# Altium schematic sheet 9.PCA9685.SchDoc(9.PCA9685)
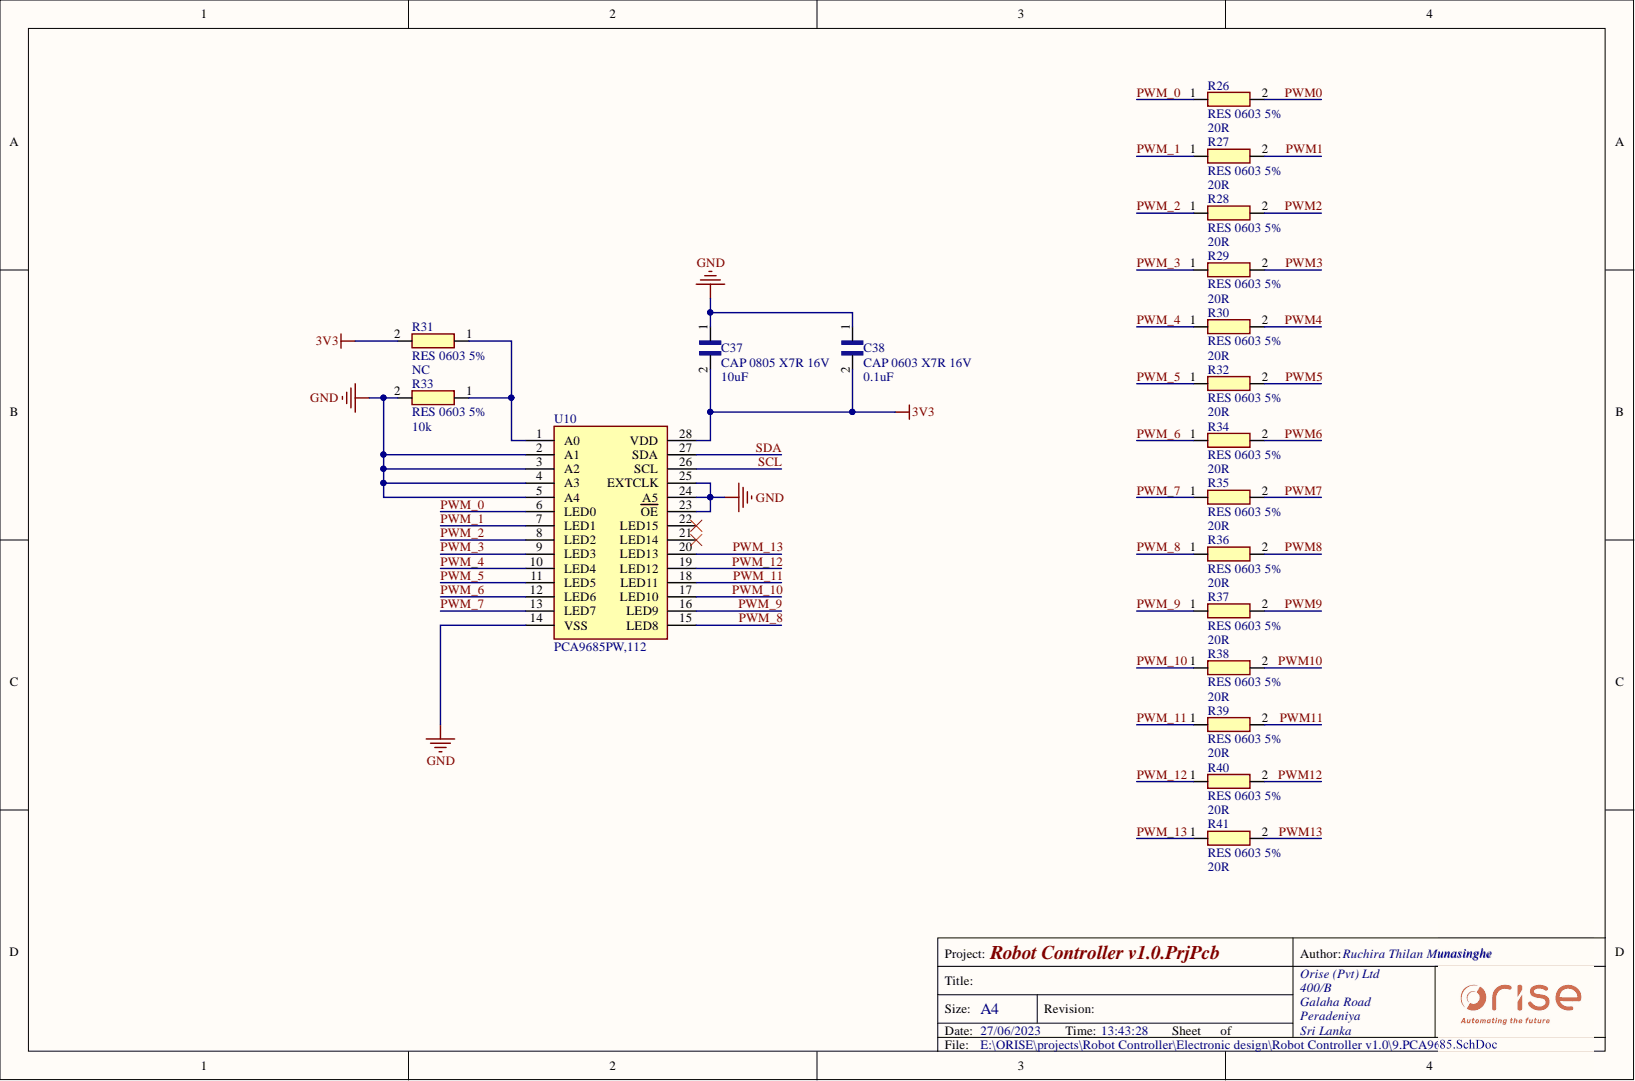
[diagram p0: 9.PCA9685.SchDoc]

## Parts
C37  CAP 0805 X7R 16V  value 10uF  [CAP 0805]
C38  CAP 0603 X7R 16V  value 0.1uF  [CAP 0603]
R26  RES 0603 5%  [RES 0603]
R27  RES 0603 5%  value 20R  [RES 0603]
R28  RES 0603 5%  value 20R  [RES 0603]
R29  RES 0603 5%  value 20R  [RES 0603]
R30  RES 0603 5%  value 20R  [RES 0603]
R31  RES 0603 5%  value NC  [RES 0603 NC]
R32  RES 0603 5%  value 20R  [RES 0603]
R33  RES 0603 5%  value 10k  [RES 0603]
R34  RES 0603 5%  value 20R  [RES 0603]
R35  RES 0603 5%  value 20R  [RES 0603]
R36  RES 0603 5%  value 20R  [RES 0603]
R37  RES 0603 5%  value 20R  [RES 0603]
R38  RES 0603 5%  value 20R  [RES 0603]
R39  RES 0603 5%  value 20R  [RES 0603]
R40  RES 0603 5%  value 20R  [RES 0603]
R41  RES 0603 5%  value 20R  [RES 0603]
U10  PCA9685PW,112  [PC9685]

## Nets
3V3: C37.2 C38.2 R31.2 U10.28(VDD)
GND: C37.1 C38.1 R33.2 U10.2(A1) U10.3(A2) U10.4(A3) U10.5(A4) U10.14(VSS) U10.23(OE) U10.24(A5) U10.25(EXTCLK)
PWM0: R26.2
PWM1: R27.2
PWM2: R28.2
PWM3: R29.2
PWM4: R30.2
PWM5: R32.2
PWM6: R34.2
PWM7: R35.2
PWM8: R36.2
PWM9: R37.2
PWM10: R38.2
PWM11: R39.2
PWM12: R40.2
PWM13: R41.2
PWM_0: R26.1 U10.6(LED0)
PWM_1: R27.1 U10.7(LED1)
PWM_2: R28.1 U10.8(LED2)
PWM_3: R29.1 U10.9(LED3)
PWM_4: R30.1 U10.10(LED4)
PWM_5: R32.1 U10.11(LED5)
PWM_6: R34.1 U10.12(LED6)
PWM_7: R35.1 U10.13(LED7)
PWM_8: R36.1 U10.15(LED8)
PWM_9: R37.1 U10.16(LED9)
PWM_10: R38.1 U10.17(LED10)
PWM_11: R39.1 U10.18(LED11)
PWM_12: R40.1 U10.19(LED12)
PWM_13: R41.1 U10.20(LED13)
SCL: U10.26(SCL)
SDA: U10.27(SDA)
NetR31_1: R31.1 R33.1 U10.1(A0)

Not connected: U10 21(LED14) 22(LED15)

## Library data of the parts
R26 = RES 0603 5%: value: 20R; Library Name: Robot Controller v1.0.SchLib; Description: RES 0603 5%; Component Kind: Standard
R31 = RES 0603 5% NC: value: NC; Library Name: Robot Controller v1.0.SchLib; Description: RES 0603 5%; Component Kind: Standard
U10 = PCA9685PW,112: Integrated Circuit; Manufacturer_Name: NXP; Mouser Price/Stock: https://www.mouser.co.uk/ProductDetail/NXP-Semiconductors/PCA9685PW112/?qs=6YAT8rHPFU9wZqiAeiOMZw%3D%3D; Mouser Part Number: 771-PCA9685PW,112; Manufacturer_Part_Number: PCA9685PW,112; Library Name: Robot Controller v1.0.SchLib; Height: 1.1mm; Datasheet Link: http://www.nxp.com/docs/en/data-sheet/PCA9685.pdf; Component Kind: Standard; Arrow Price/Stock: https://www.arrow.com/en/products/pca9685pw112/nxp-semiconductors; Arrow Part Number: PCA9685PW,112
C37 = CAP 0805 X7R 16V: Library Name: Robot Controller v1.0.SchLib; Description: CAP 0805 X7R 16V; Component Kind: Standard
C38 = CAP 0603 X7R 16V: Library Name: Robot Controller v1.0.SchLib; Description: CAP 0603 X7R 16V; Component Kind: Standard
R27-R30 R32-R41 = RES 0603 5%: Library Name: Robot Controller v1.0.SchLib; Description: RES 0603 5%; Component Kind: Standard
